# Altium schematic sheet 齐纳安全栅.SchDoc
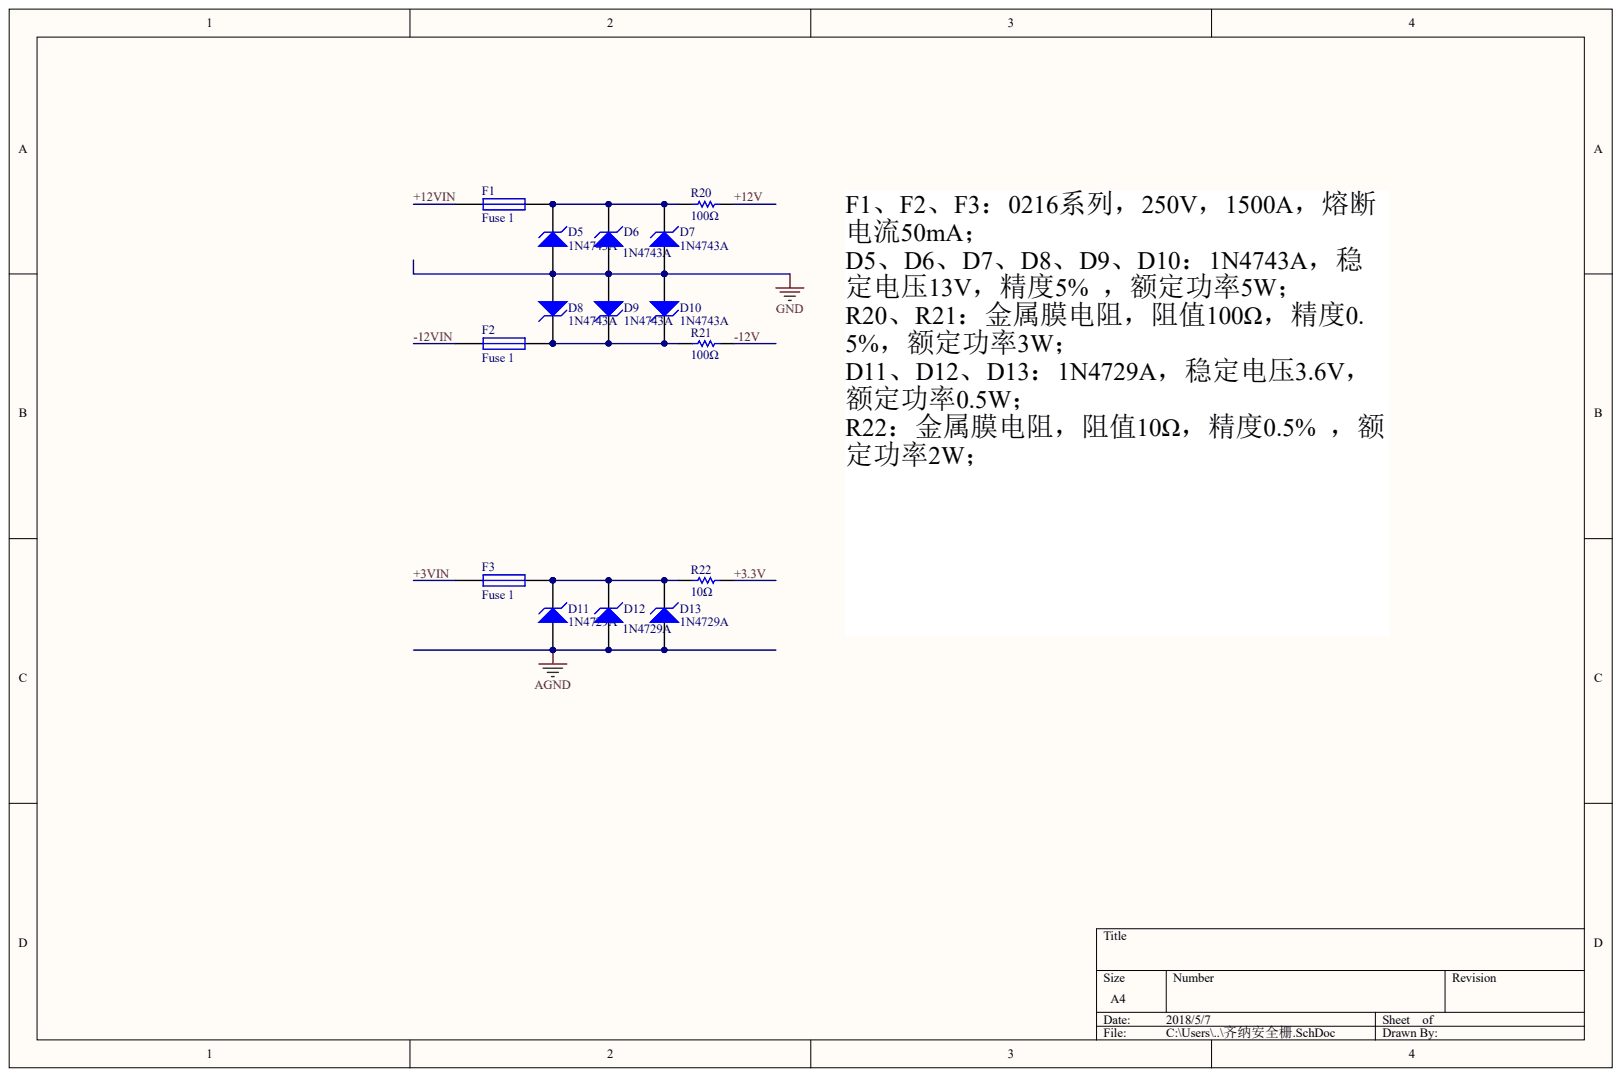
[diagram p0: 齐纳安全栅.SchDoc]

## Parts
D5  1N4743A
D6  1N4743A
D7  1N4743A
D8  1N4743A
D9  1N4743A
D10  1N4743A
D11  1N4729A
D12  1N4729A
D13  1N4729A
F1  Fuse 1
F2  Fuse 1
F3  Fuse 1
R20  100Ω
R21  100Ω
R22  10Ω

## Nets
AGND: D11.1 D12.1 D13.1
GND: D5.1 D6.1 D7.1 D8.1 D9.1 D10.1
+3.3V: R22.2
+3VIN: F3.1
+12V: R20.2
+12VIN: F1.1
-12V: R21.2
-12VIN: F2.1
NetD5_2: D5.2 D6.2 D7.2 F1.2 R20.1
NetD8_2: D8.2 D9.2 D10.2 F2.2 R21.1
NetD11_2: D11.2 D12.2 D13.2 F3.2 R22.1

## Library data of the parts
R20-R22 = (no symbol name): Value: 100; Published: 8-Jun-2000; LatestRevisionDate: 17-Jul-2002; LatestRevisionNote: Re-released for DXP Platform.; PackageReference: AXIAL-0.3; PackageDescription: Axial Device, Thru-Hole; 2 Leads; 0.3 in Pin Spacing; Publisher: Altium Limited
D5-D13 F1-F3 = (no symbol name): Published: 8-Jun-2000; LatestRevisionDate: 17-Jul-2002; LatestRevisionNote: Re-released for DXP Platform.; Publisher: Altium Limited
  D5-D13: PackageReference: DIODE-0.7; PackageDescription: Diode, Thru-Hole; 2 Leads; 0.7 in Pin Spacing
  F1-F3: PackageReference: PIN-W2/E2.8; PackageDescription: Thru-Hole; 2 Leads
